# Altium schematic sheet Power.SchDoc(Power)
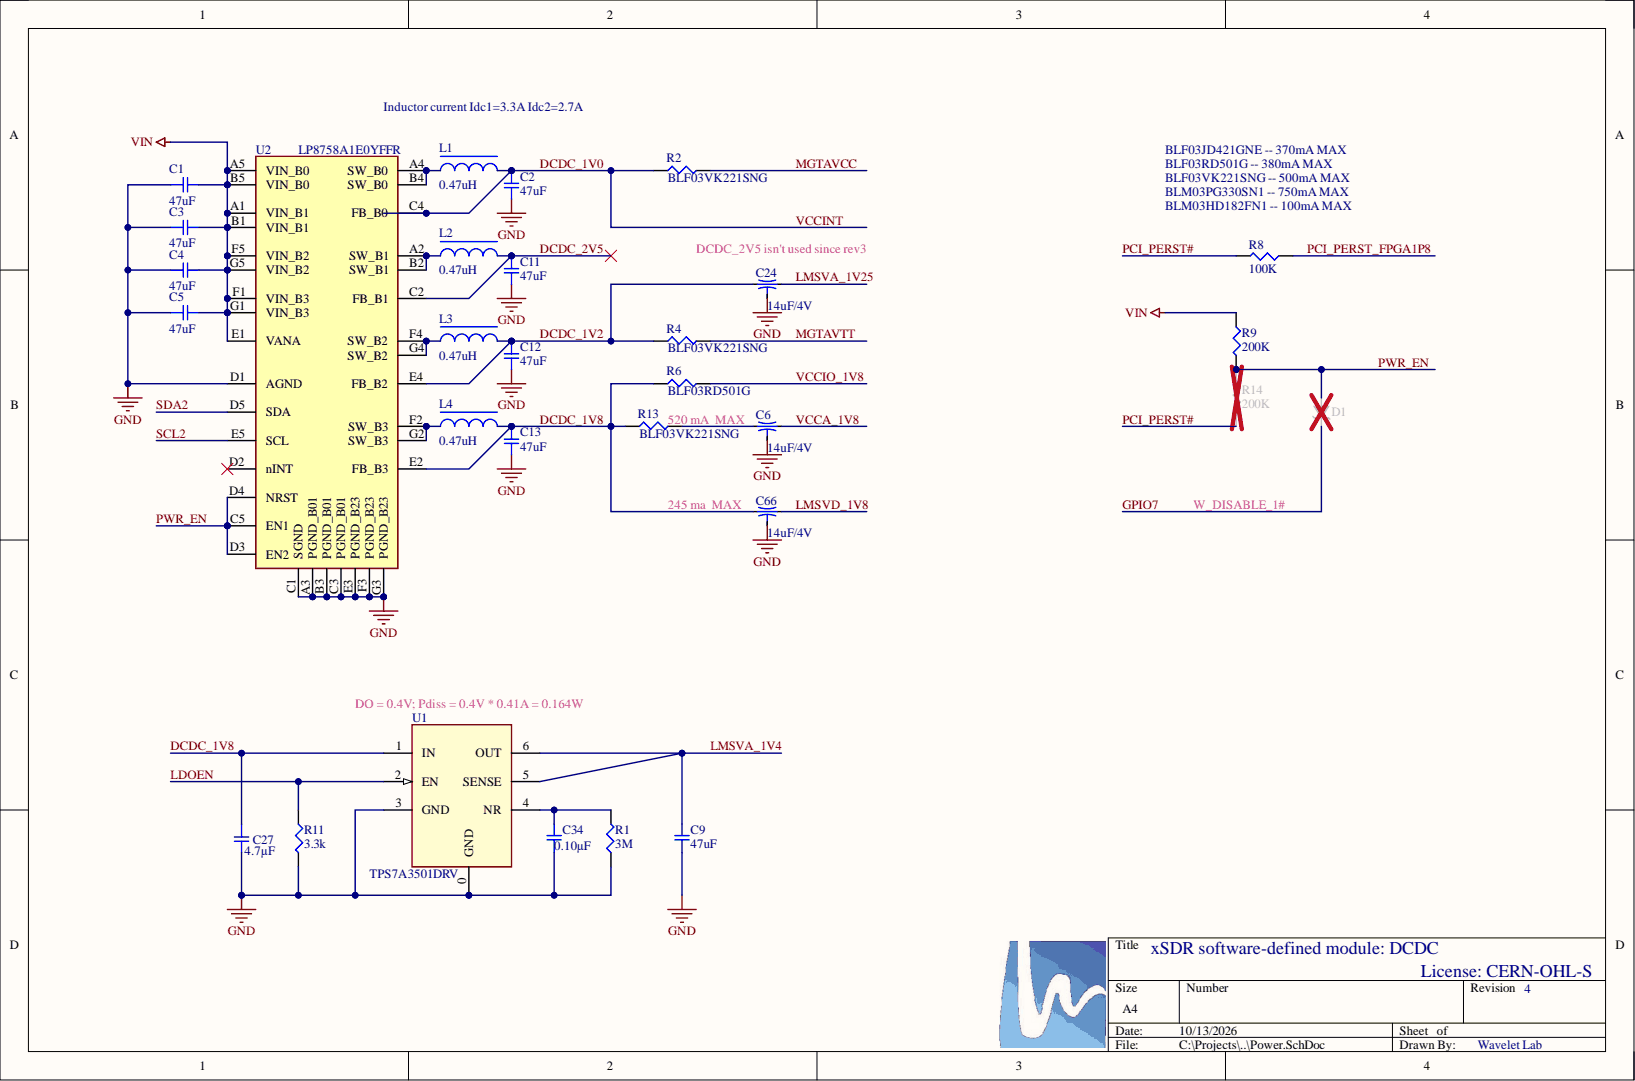
[diagram p0: Power.SchDoc]

## Parts
C1  47uF  [SMD-0603-CAP_HighDensity]
C2  47uF  [SMD-0603-CAP_HighDensity]
C3  47uF  [SMD-0603-CAP_HighDensity]
C4  47uF  [SMD-0603-CAP_HighDensity]
C5  47uF  [SMD-0603-CAP_HighDensity]
C6  14uF/4V  [NFM15]
C9  47uF  [SMD-0603-CAP_HighDensity]
C11  47uF  [SMD-0603-CAP_HighDensity]
C12  47uF  [SMD-0603-CAP_HighDensity]
C13  47uF  [SMD-0603-CAP_HighDensity]
C24  14uF/4V  [NFM15]
C27  value 4.7µF  [SMD-0201C]
C34  value 0.10µF  [SMD-0201C]
C66  14uF/4V  [NFM15]
D1  SDM02M30LP3  [X3-DFN0603-2]  (value not drawn)
L1  0.47uH  [LSCNx1608]
L2  0.47uH  [LSCNx1608]
L3  0.47uH  [LSCNx1608]
L4  0.47uH  [LSCNx1608]
R1  value 3M  [SMD-0201-RES]
R2  value BLF03VK221SNG  [SMD-0201-RES]
R4  value BLF03VK221SNG  [SMD-0201-RES]
R6  value BLF03RD501G  [SMD-0201-RES]
R8  100K  [SMD-0201-RES]
R9  200K  [SMD-0201-RES]
R11  value 3.3k  [SMD-0201-RES]
R13  value BLF03VK221SNG  [SMD-0201-RES]
R14  200K  [SMD-0201-RES]
U1  Name: TPS7A3501DRV  [W-SON-6]
U2  Name: LP8758A1E0YFFR  [BGA WLCSP35]

## Nets
GND: C1.1 C2.2 C3.1 C4.1 C5.1 C6.2 C9.1 C11.2 C12.2 C13.2 C24.2 C27.2 C34.1 C66.2 R1.1 R11.2 U1.0(GND) U1.3(GND) U2.A3(PGND_B01) U2.B3(PGND_B01) U2.C1(SGND) U2.C3(PGND_B01) U2.D1(AGND) U2.E3(PGND_B23) U2.F3(PGND_B23) U2.G3(PGND_B23)
VIN: C1.2 C3.2 C4.2 C5.2 R9.1 U2.A1(VIN_B1) U2.A5(VIN_B0) U2.B1(VIN_B1) U2.B5(VIN_B0) U2.E1(VANA) U2.F1(VIN_B3) U2.F5(VIN_B2) U2.G1(VIN_B3) U2.G5(VIN_B2)
DCDC_1V0: C2.1 L1.2 R2.1 U2.C4(FB_B0)
DCDC_1V2: C12.1 C24.1 L3.2 R4.1 U2.E4(FB_B2)
DCDC_1V8: C13.1 C27.1 C66.1 L4.2 R6.1 R13.1 U1.1(IN) U2.E2(FB_B3)
DCDC_2V5: C11.1 L2.2 U2.C2(FB_B1)
GPIO7: D1.C
LDOEN: R11.1 U1.2(EN)
LMSVA_1V4: C9.2 U1.5(SENSE) U1.6(OUT)
LMSVA_1V25: C24.3
LMSVD_1V8: C66.3
MGTAVCC: R2.2
MGTAVTT: R4.2
PCI_PERST#: R8.2 R14.2
PCI_PERST_FPGA1P8: R8.1
PWR_EN: D1.A R9.2 R14.1 U2.C5(EN1) U2.D3(EN2) U2.D4(NRST)
SCL2: U2.E5(SCL)
SDA2: U2.D5(SDA)
VCCA_1V8: C6.3
VCCIO_1V8: R6.2
NetC6_1: C6.1 R13.2
NetC34_2: C34.2 R1.2 U1.4(NR)
NetL1_1: L1.1 U2.A4(SW_B0) U2.B4(SW_B0)
NetL2_1: L2.1 U2.A2(SW_B1) U2.B2(SW_B1)
NetL3_1: L3.1 U2.F4(SW_B2) U2.G4(SW_B2)
NetL4_1: L4.1 U2.F2(SW_B3) U2.G2(SW_B3)

Not connected: U2 D2(nINT)

## Library data of the parts
C1-C5 C9 C11-C13 = GRM188R60G476ME15D: CAP CER 47UF 4V X5R 0603; Manufacturer: Murata Electronics North America; Tolerance: ±20%; Applications: General Purpose; Component Kind: Standard; Datasheets: https://www.murata.com/-/media/webrenewal/support/library/catalog/products/capacitor/ceramiccapacitor/c02e.ashx?la=en-us&cvid=20210120024548000000; Digi-Key Part Number: 490-13246-2-ND; Failure Rate: Surface Mount, MLCC; Mounting Type: 0603 (1608 Metric); Operating Temperature: -55°C ~ 85°C; Package / Case: 0.063" L x 0.031" W (1.60mm x 0.80mm); Part Number: GRM188R60G476ME15D; Part Status: Active; Series: GRM; Temperature Coefficient: X5R; Value: 47uF; Voltage: 4V; Library Name: USDR.IntLib
C6 C24 C66 = NFM15: Filter EMI 0402 Feedthrough; Manufacturer: MuRata; Name: NFM15PC146R0G3D; Tolerance: 20%; U/P/TKE: 4V/2A/30mO/-55+85℃; Component Kind: Standard; Link: https://octopart.com/nfm15pc146r0g3d-murata-94414995; PDF: https://datasheet.octopart.com/NFM15PC146R0G3D-Murata-datasheet-138760002.pdf; Value: 14uF/4V; Library Name: USDR.IntLib
C27 = GRM035R60J475ME15D: CAP CER 4.7UF 6.3V X5R 0201; Manufacturer: Murata Electronics North America; @ qty: 0; Minimum Quantity: 1; Tolerance: ±20%; Unit Price (USD): 0.54; Applications: General Purpose; Component Kind: Standard; Datasheets: http://psearch.en.murata.com/capacitor/product/GRM035R60J475ME15%23.pdf; Digi-Key Part Number: 490-13230-1-ND; Factory Stock: 0; Failure Rate: Surface Mount, MLCC; Features: Derating Recommended; Height - Seated (Max): 0.022" (0.55mm); Image: //media.digikey.com/Renders/Murata%20Renders/ZRB-SERIES-0402.jpg; Lead Spacing: -; Mounting Type: 0201 (0603 Metric); Operating Temperature: -55°C ~ 85°C; Package / Case: 0.024" L x 0.012" W (0.60mm x 0.30mm); Packaging: Cut Tape (CT); Part Number: GRM035R60J475ME15D; Part Status: Active; Quantity Available: 67188; Ratings: -; Series: GRM; Size / Dimension: -; Temperature Coefficient: X5R; Thickness (Max): -; Voltage: 6.3V; Library Name: USDR.IntLib
C34 = GRM033R60J104ME19D: CAP CER 0.1UF 6.3V X5R 0201; Manufacturer: Murata Electronics North America; @ qty: 0; Minimum Quantity: 1; Tolerance: ±20%; Unit Price (USD): 0.1; Applications: General Purpose; Component Kind: Standard; Datasheets: http://www.murata.com/~/media/webrenewal/support/library/catalog/products/capacitor/mlcc/c02e.pdf; Digi-Key Part Number: 490-5404-1-ND; Factory Stock: 0; Failure Rate: Surface Mount, MLCC; Features: -; Height - Seated (Max): 0.013" (0.33mm); Image: //media.digikey.com/Renders/Murata%20Renders/ZRB-SERIES-0402.jpg; Lead Spacing: -; Mounting Type: 0201 (0603 Metric); Operating Temperature: -55°C ~ 85°C; Package / Case: 0.024" L x 0.012" W (0.60mm x 0.30mm); Packaging: Cut Tape (CT); Part Number: GRM033R60J104ME19D; Part Status: Active; Quantity Available: 381529; Ratings: -; Series: GRM; Size / Dimension: -; Temperature Coefficient: X5R; Thickness (Max): -; Voltage: 6.3V; Library Name: USDR.IntLib
D1 = SDM02M30LP3: Diode, Schottky, 30V, 0.1A, 0.3Vf, -50/+125, DFN-0603; Manufacturer: Toshiba; Component Kind: Standard; Library Name: Diode_Transistor.IntLib; Value: SDM02M30LP3
L1-L4 = LSCNx1608: Coil wire wound; Manufacturer: Taiyo Yuden; Previous Part Number: MCHK1608TR47MKN; Name: LSCNB1608HKTR47MD; Tolerance: ±20%; Component Kind: Standard; Link: https://ds.yuden.co.jp/TYCOMPAS/ut/specSheet?pn=LSCNB1608HKTR47MD; Value: 0.47uH; Library Name: USDR.IntLib
R1 = ERJ-1GEJ244C: RES SMD 3M OHM 5% 1/20W 0201; Manufacturer: Panasonic Electronic Components; @ qty: 1500; Factory Stock: 0; Minimum Quantity: 1; Power (Watts): 0.05W, 1/20W; Temperature Coefficient: ±200ppm/°C; Unit Price (USD): 0.00787; Component Kind: Standard; Composition: Thick Film; Datasheets: //media.digikey.com/pdf/Data%20Sheets/Panasonic%20Resistors%20Thermistors%20PDFs/ERJ%205%25.pdf; Digi-Key Part Number: P240KAGCT-ND; Failure Rate: -; Features: -; Height - Seated (Max): 0.010" (0.26mm); Image: //media.digikey.com/Renders/Panasonic%20Renders/ERJ-0201%20Pkg.jpg; Number of Terminations: 2; Operating Temperature: -55°C ~ 125°C; Package / Case: 0201 (0603 Metric); Packaging: Cut Tape (CT); Part Number: ERJ-1GEJ244C; Part Status: Active; Quantity Available: 51508; Series: ERJ; Size / Dimension: 0.024" L x 0.012" W (0.60mm x 0.30mm); Supplier Device Package: 0201; Tolerance: ±5%; Library Name: USDR.IntLib
R2 R4 R6 R13 = ERJ-1GE0R00C: RES SMD 0.0OHM JUMPER 1/20W 0201; Manufacturer: Panasonic Electronic Components; @ qty: 1500; Factory Stock: 0; Minimum Quantity: 1; Power (Watts): 0.05W, 1/20W; Unit Price (USD): 0.00960; Component Kind: Standard; Composition: Thick Film; Datasheets: //media.digikey.com/pdf/Data%20Sheets/Panasonic%20Resistors%20Thermistors%20PDFs/ERJ_G_Rev06.pdf; Digi-Key Part Number: P0.0AGCT-ND; Failure Rate: -; Features: -; Height - Seated (Max): 0.010" (0.26mm); Image: //media.digikey.com/Renders/Panasonic%20Renders/ERJ-0201%20Pkg.jpg; Number of Terminations: 2; Operating Temperature: -55°C ~ 125°C; Package / Case: 0201 (0603 Metric); Packaging: Cut Tape (CT); Part Number: ERJ-1GE0R00C; Part Status: Active; Quantity Available: 2883022; Series: ERJ; Size / Dimension: 0.024" L x 0.012" W (0.60mm x 0.30mm); Supplier Device Package: 0201; Temperature Coefficient: -; Tolerance: Jumper; Library Name: USDR.IntLib
R8 = ERJ-1GEJ103C: RES SMD 100K 5% 1/20W 0201; Manufacturer: Panasonic Electronic Components; Part Number: ERJ-1GEJ104C; @ qty: 1500; Factory Stock: 0; Minimum Quantity: 1; Power (Watts): 0.05W, 1/20W; Temperature Coefficient: ±200ppm/°C; Unit Price (USD): 0.00787; Component Kind: Standard; Composition: Thick Film; Datasheets: //media.digikey.com/pdf/Data%20Sheets/Panasonic%20Resistors%20Thermistors%20PDFs/ERJ%205%25.pdf; Digi-Key Part Number: P10KAGCT-ND; Failure Rate: -; Features: -; Height - Seated (Max): 0.010" (0.26mm); Image: //media.digikey.com/Renders/Panasonic%20Renders/ERJ-0201%20Pkg.jpg; Library Name: Resistors.IntLib; Number of Terminations: 2; Operating Temperature: -55°C ~ 125°C; Package / Case: 0201 (0603 Metric); Packaging: Cut Tape (CT); Part Status: Active; Quantity Available: 115724; Series: ERJ; Size / Dimension: 0.024" L x 0.012" W (0.60mm x 0.30mm); Supplier Device Package: 0201; Tolerance: ±5%; Value: 100K
R9 R14 = ERJ-1GEJ103C: RES SMD 200K 5% 1/20W 0201; Manufacturer: Panasonic Electronic Components; Part Number: ERJ-1GEJ204C; @ qty: 1500; Factory Stock: 0; Minimum Quantity: 1; Power (Watts): 0.05W, 1/20W; Temperature Coefficient: ±200ppm/°C; Unit Price (USD): 0.00787; Component Kind: Standard; Composition: Thick Film; Datasheets: //media.digikey.com/pdf/Data%20Sheets/Panasonic%20Resistors%20Thermistors%20PDFs/ERJ%205%25.pdf; Digi-Key Part Number: P10KAGCT-ND; Failure Rate: -; Features: -; Height - Seated (Max): 0.010" (0.26mm); Image: //media.digikey.com/Renders/Panasonic%20Renders/ERJ-0201%20Pkg.jpg; Library Name: Resistors.IntLib; Number of Terminations: 2; Operating Temperature: -55°C ~ 125°C; Package / Case: 0201 (0603 Metric); Packaging: Cut Tape (CT); Part Status: Active; Quantity Available: 115724; Series: ERJ; Size / Dimension: 0.024" L x 0.012" W (0.60mm x 0.30mm); Supplier Device Package: 0201; Tolerance: ±5%; Value: 200K
R11 = ERJ-1GEJ332C: RES SMD 3.3K OHM 5% 1/20W 0201; Manufacturer: Panasonic Electronic Components; @ qty: 1500; Factory Stock: 0; Minimum Quantity: 1; Power (Watts): 0.05W, 1/20W; Temperature Coefficient: ±200ppm/°C; Unit Price (USD): 0.00787; Component Kind: Standard; Composition: Thick Film; Datasheets: //media.digikey.com/pdf/Data%20Sheets/Panasonic%20Resistors%20Thermistors%20PDFs/ERJ%205%25.pdf; Digi-Key Part Number: P3.3KAGCT-ND; Failure Rate: -; Features: -; Height - Seated (Max): 0.010" (0.26mm); Image: //media.digikey.com/Renders/Panasonic%20Renders/ERJ-0201%20Pkg.jpg; Number of Terminations: 2; Operating Temperature: -55°C ~ 125°C; Package / Case: 0201 (0603 Metric); Packaging: Cut Tape (CT); Part Number: ERJ-1GEJ332C; Part Status: Active; Quantity Available: 45455; Series: ERJ; Size / Dimension: 0.024" L x 0.012" W (0.60mm x 0.30mm); Supplier Device Package: 0201; Tolerance: ±5%; Library Name: USDR.IntLib
U1 = TPS7A3501: IC; Manufacturer: TI; Misc: step down switching regulator; Swap: No; Component Kind: Standard; ComponentLink1Description: Link; ComponentLink1URL: https://octopart.com/search?q=TPS7A3501DRV&currency=USD&specs=0&in_stock_only=1; Library Name: USDR.IntLib
U2 = LP8758-E0: IC 4xDC/DC; Manufacturer: TI; Misc: 4x  4A DC/DCs, i2c control; Swap: No; U/P/TKE: 2.5-5.5V to 0.5-3.3V; Component Kind: Standard; Link: https://octopart.com/lp8758a1e0yffr-texas+instruments-68058967; Library Name: USDR.IntLib
